# Altium schematic sheet CAN_Transceiver Breakout Board .SchDoc
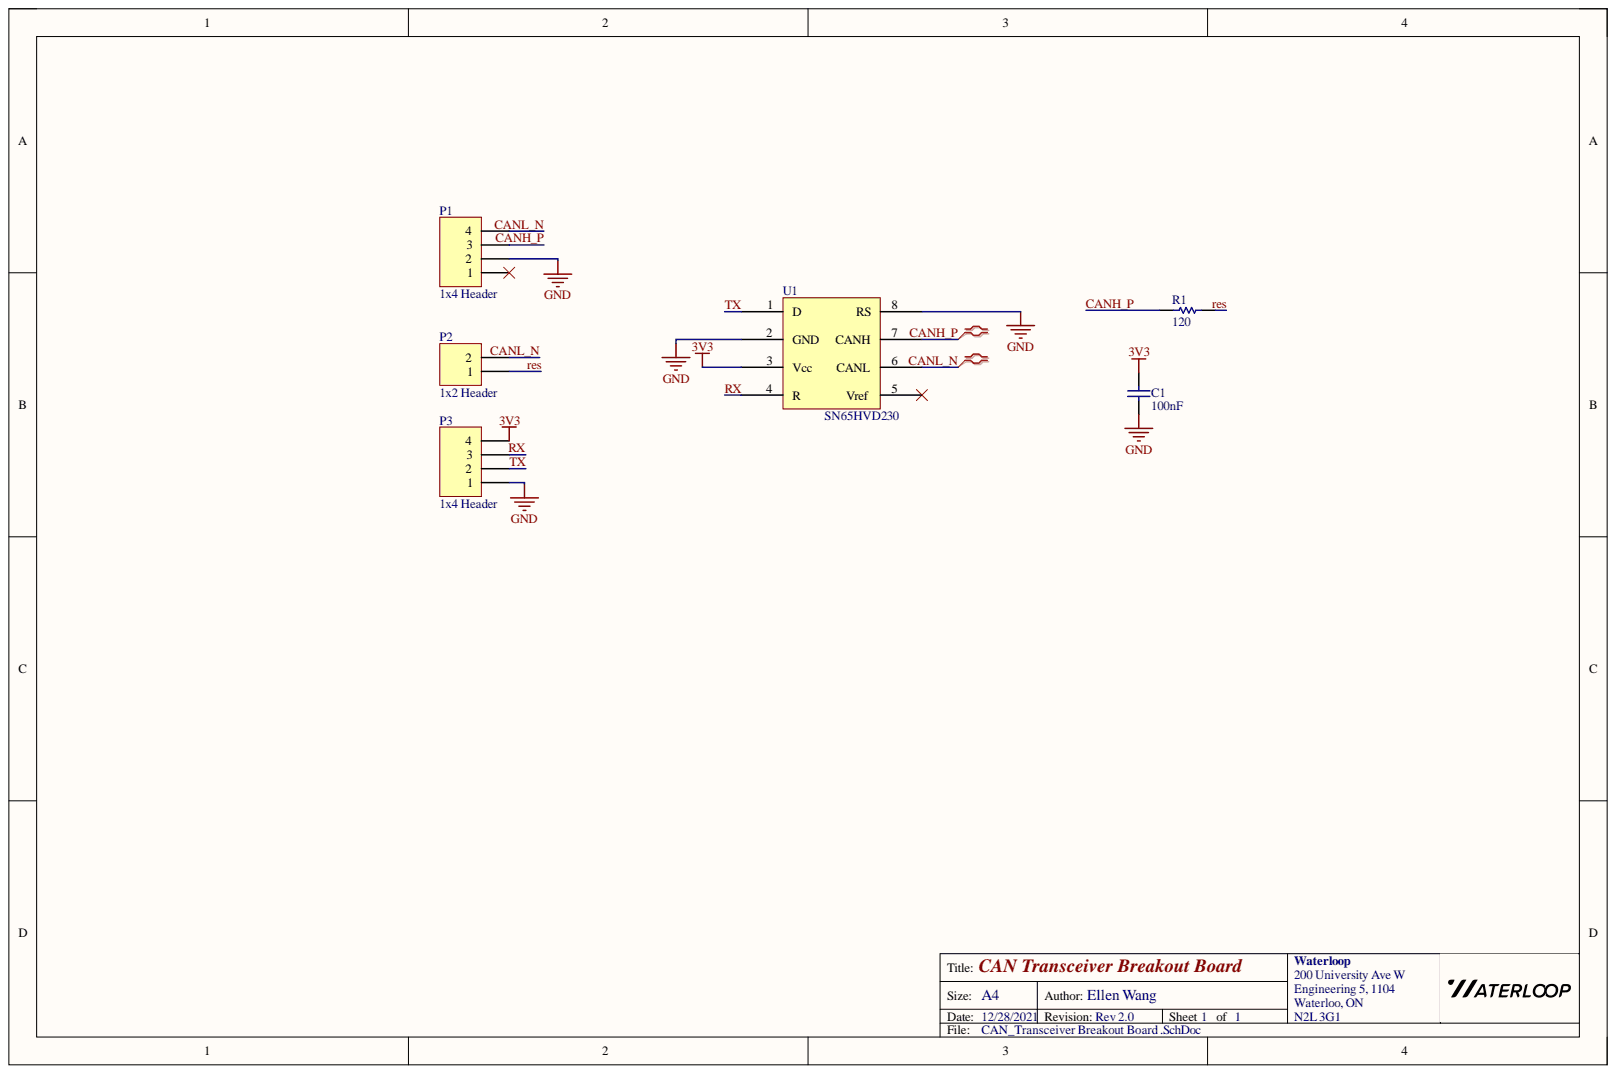
[diagram p0: CAN_Transceiver Breakout Board .SchDoc]

## Parts
C1  value 100nF  [0805 - Capacitor]  (hidden comment: Capacitor - Nonpolarized)
P1  1x4 Header  [HDR1X4]
P2  1x2 Header  [HDR1X2]
P3  1x4 Header  [HDR1X4]
R1  value 120  [0603 - Resistor]  (hidden comment: Resistor)
U1  SN65HVD230  [SN65HVD230]

## Nets
3V3: C1.2 P3.4 U1.3(Vcc)
GND: C1.1 P1.2 P3.1 U1.2(GND) U1.8(RS)
CANH_P: P1.3 R1.1 U1.7(CANH)
CANL_N: P1.4 P2.2 U1.6(CANL)
res: P2.1 R1.2
RX: P3.3 U1.4(R)
TX: P3.2 U1.1(D)

Not connected: P1 1; U1 5(Vref)

## Library data of the parts
C1 = Capacitor - Nonpolarized: Nonpolarized Capacitor; Manufacturer: YAGEO; Manufacturer Part Number: CC0805KPX7R9BB104; Component Kind: Standard; Library Name: General.SchLib; Signal Integrity: Cap; Simulation: CAP; Supplier: Digikey; Supplier Part Number: 13-CC0805KPX7R9BB104CT-ND
P1 P3 = 1x4 Header: 1x4 Pin Header; Component Kind: Standard; Library Name: GeneralConnectors.SchLib; Signal Integrity: Connector
P2 = 1x2 Header: 1x2 Pin Header; Component Kind: Standard; Library Name: GeneralConnectors.SchLib; Signal Integrity: Connector
R1 = Resistor: Manufacturer: YAGEO; Manufacturer Part Number: RC0603FR-10120RL; Component Kind: Standard; Description: Resistor; Library Name: General.SchLib; Signal Integrity: Res; Simulation: RESISTOR; Supplier: Digikey; Supplier Part Number: 13-RC0603FR-10120RLCT-ND
U1 = SN65HVD230: 3.3V CAN Transeiver with standby; Component Kind: Standard; Library Name: General.SchLib
